# Altium schematic sheet W65C22N6TPLG-14 to DIP VIA 6522 Adapter.SchDoc("W65C22N6TPLG-14 to DIP VIA 6522 Adapter")
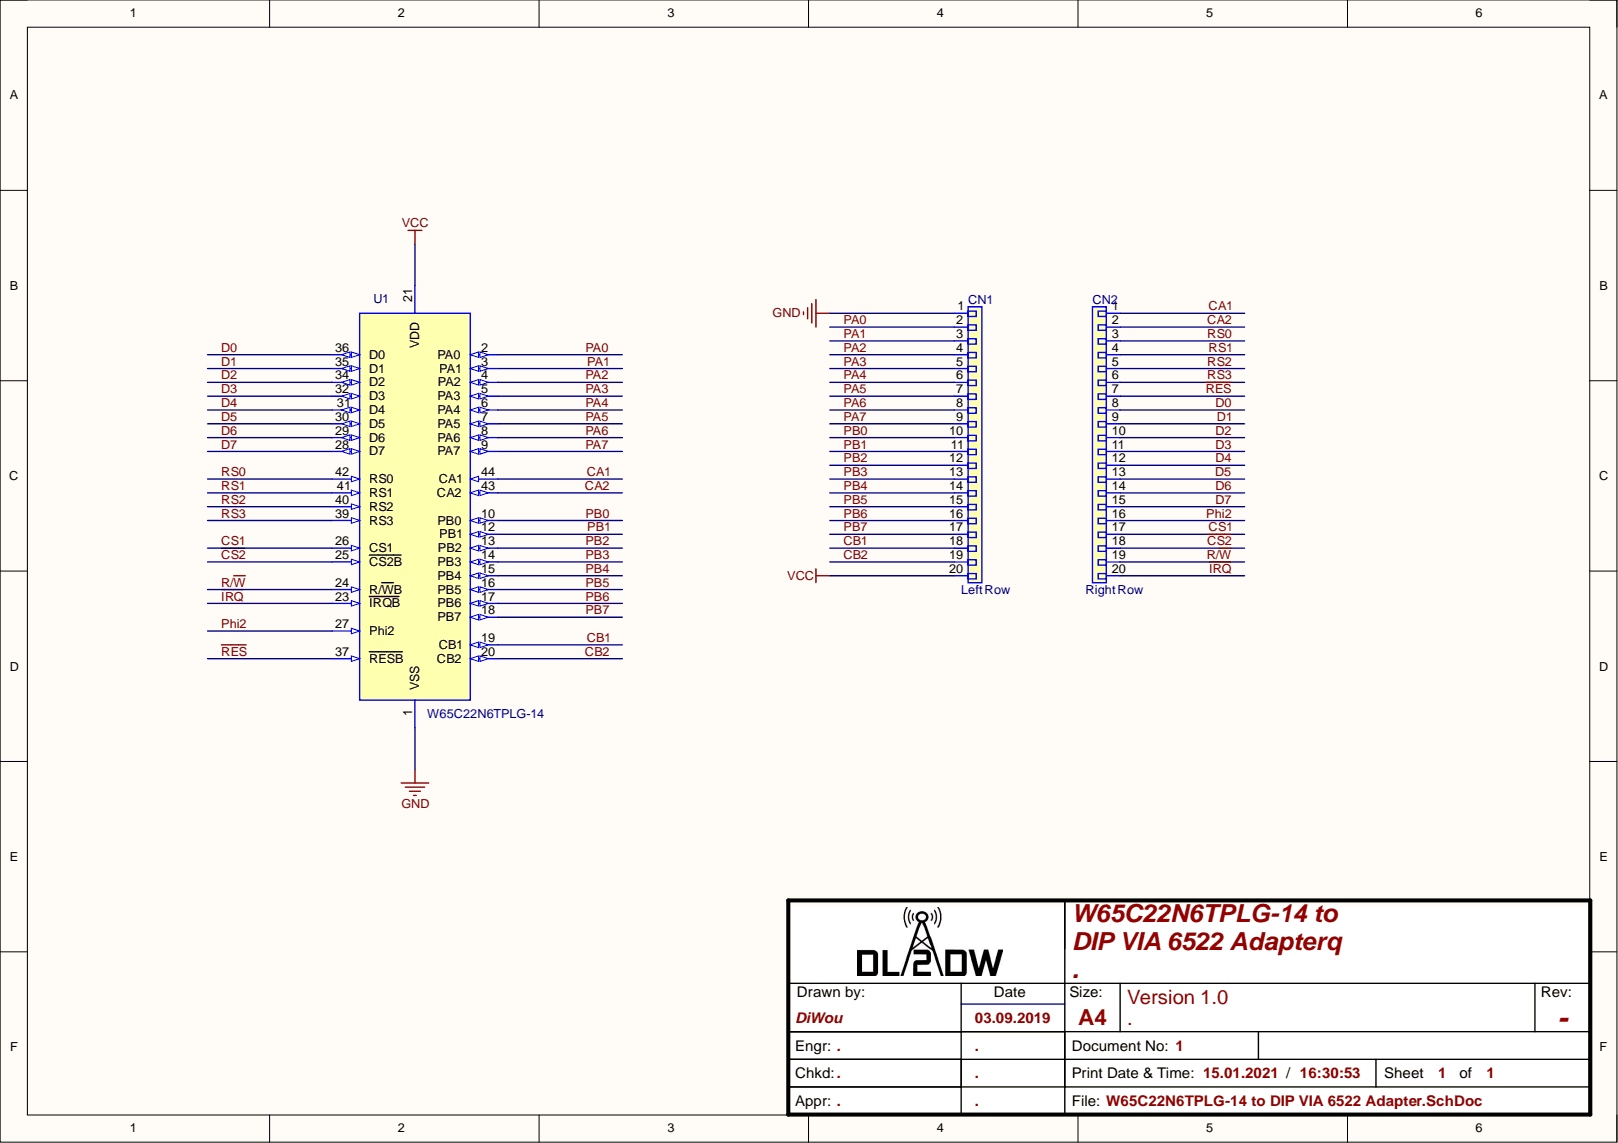
[diagram p0: W65C22N6TPLG-14 to DIP VIA 6522 Adapter.SchDoc]

## Parts
CN1  Left Row  [Pin Header 01x20 2.54mm precision Horizontal Male]
CN2  Right Row  [Pin Header 01x20 2.54mm precision Horizontal Male]
U1  W65C22N6TPLG-14  [W65C22N6TPLG-14 with PLCC Socket]

## Nets
GND: CN1.1 U1.1(VSS)
VCC: CN1.20 U1.21(VDD)
~{CS2}: CN2.18 U1.25(CS2B)
CA1: CN2.1 U1.44(CA1)
CA2: CN2.2 U1.43(CA2)
CB1: CN1.18 U1.19(CB1)
CB2: CN1.19 U1.20(CB2)
CS1: CN2.17 U1.26(CS1)
D0: CN2.8 U1.36(D0)
D1: CN2.9 U1.35(D1)
D2: CN2.10 U1.34(D2)
D3: CN2.11 U1.32(D3)
D4: CN2.12 U1.31(D4)
D5: CN2.13 U1.30(D5)
D6: CN2.14 U1.29(D6)
D7: CN2.15 U1.28(D7)
~{IRQ}: CN2.20 U1.23(IRQB)
PA0: CN1.2 U1.2(PA0)
PA1: CN1.3 U1.3(PA1)
PA2: CN1.4 U1.4(PA2)
PA3: CN1.5 U1.5(PA3)
PA4: CN1.6 U1.6(PA4)
PA5: CN1.7 U1.7(PA5)
PA6: CN1.8 U1.8(PA6)
PA7: CN1.9 U1.9(PA7)
PB0: CN1.10 U1.10(PB0)
PB1: CN1.11 U1.12(PB1)
PB2: CN1.12 U1.13(PB2)
PB3: CN1.13 U1.14(PB3)
PB4: CN1.14 U1.15(PB4)
PB5: CN1.15 U1.16(PB5)
PB6: CN1.16 U1.17(PB6)
PB7: CN1.17 U1.18(PB7)
Phi2: CN2.16 U1.27(Phi2)
R/~{W}: CN2.19 U1.24(R/WB)
~{RES}: CN2.7 U1.37(RESB)
RS0: CN2.3 U1.42(RS0)
RS1: CN2.4 U1.41(RS1)
RS2: CN2.5 U1.40(RS2)
RS3: CN2.6 U1.39(RS3)

## Library data of the parts
CN1 CN2 = CMP-Connectors-Male_PIN_Headers-00031-2: Conn Header Vert 20POS 2MM; Connector Type: Male Pin; Number of Positions: 20; Pitch: 0.079inch; Color: Black; Component Kind: Standard; Contact Mating Length: 0.141inch; Contact Plating: Gold; Library Name: PCB-Tech Cloud; Mount: Through Hole; Number of Rows: 1; Package Quantity: 250; Packaging: Bulk; Termination: Solder
U1 = CMP-ICs-Specials-00024-3: I/O Controller Interface IC Versatile Interface Adapter; Data Bus Width: 8b; Interface: Serial; Operating Supply Current: 500uA; Operating Supply Voltage: 5V; Case/Package: PLCC; Component Kind: Standard; Library Name: PCB-Tech Cloud; Max Operating Temperature: 85°C; Min Operating Temperature: -40°C; Packaging: Rail/Tube; Weight: 0.084185oz
